# Altium schematic sheet main.SchDoc
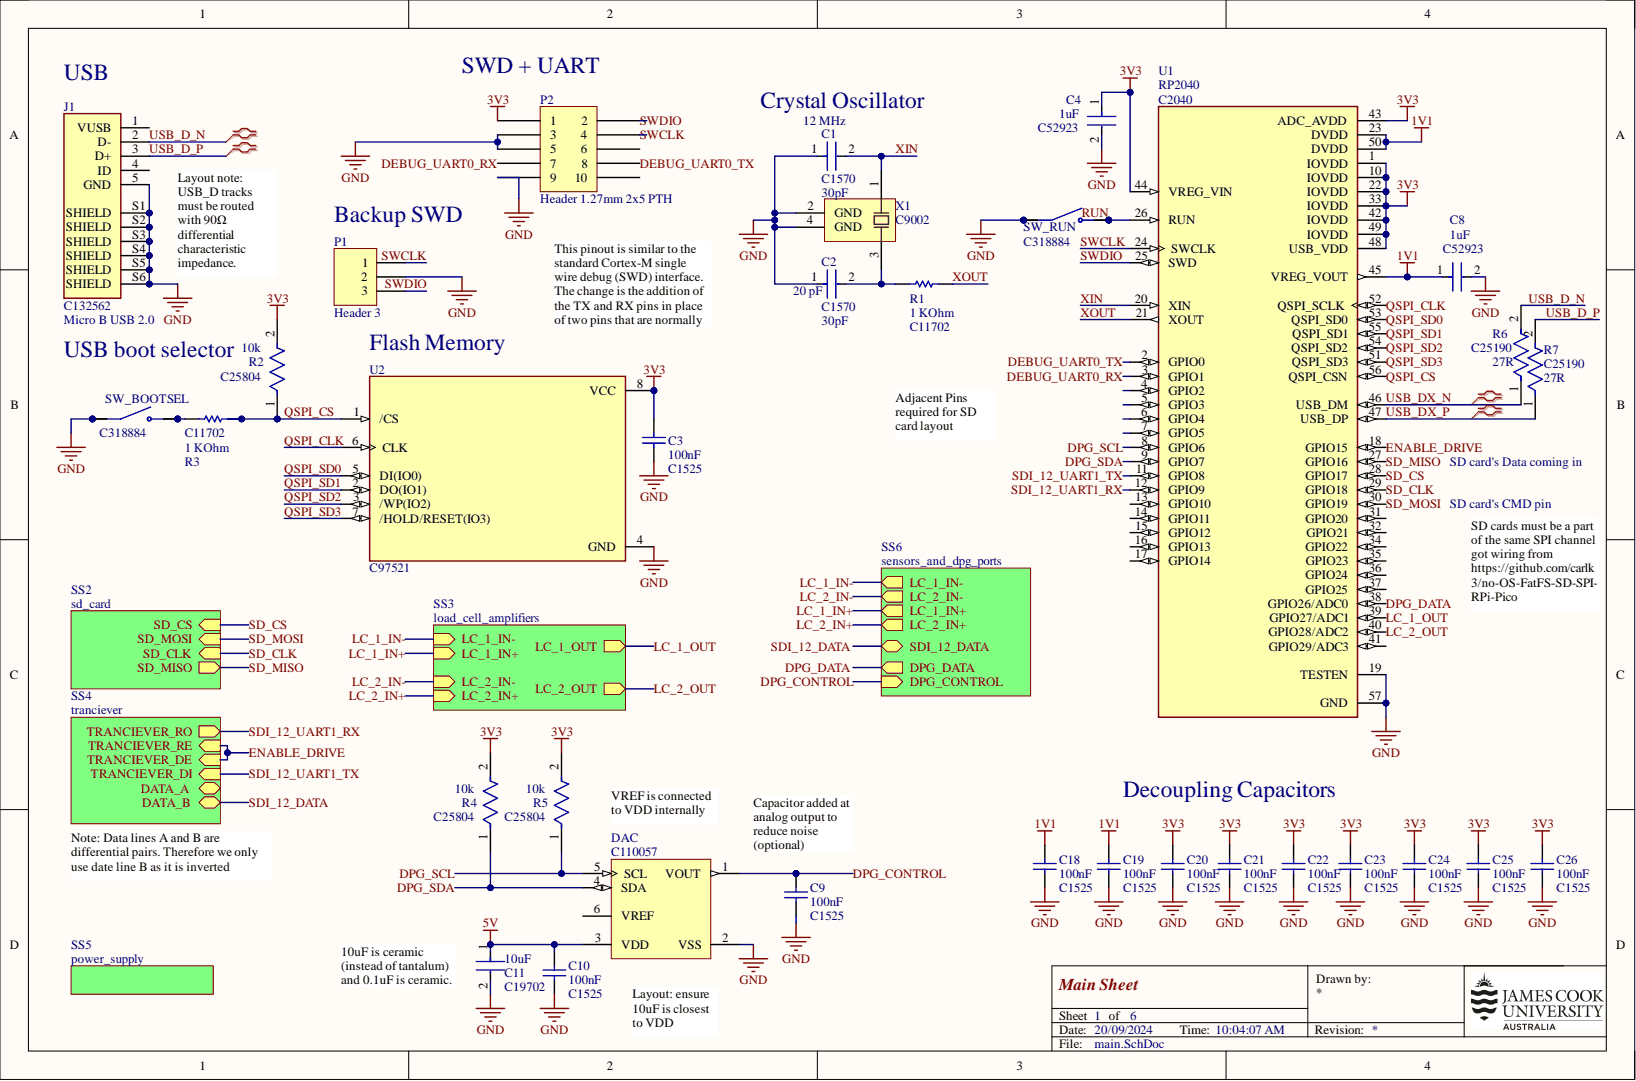
[diagram p0: main.SchDoc]

## Parts
C1  JLCPCB Part: C1570  [FP-0402-L_1_0_1-W_0_5_0_1-IPC_B]  (hidden comment: 0402CG300J500NT)
C2  Capacitance: 30pF  JLCPCB Part: C1570  [FP-0402-L_1_0_1-W_0_5_0_1-IPC_B]  (hidden comment: 0402CG300J500NT)
C3  Capacitance: 100nF  JLCPCB Part: C1525  [CAPC1050X55X23NL05T13]  (hidden comment: CL05B104KO5NNNC)
C4  Capacitance: 1uF  JLCPCB Part: C52923  [FP-CL542-IPC_B]  (hidden comment: CL05A105KA5NQNC)
C8  Capacitance: 1uF  JLCPCB Part: C52923  [FP-CL542-IPC_B]  (hidden comment: CL05A105KA5NQNC)
C9  Capacitance: 100nF  JLCPCB Part: C1525  [CAPC1050X55X23NL05T13]  (hidden comment: CL05B104KO5NNNC)
C10  Capacitance: 100nF  JLCPCB Part: C1525  [CAPC1050X55X23NL05T13]  (hidden comment: CL05B104KO5NNNC)
C11  Capacitance: 10uF  JLCPCB Part: C19702  [FP-CL10-IPC_B]  (hidden comment: CL10A106KP8NNNC)
C18  Capacitance: 100nF  JLCPCB Part: C1525  [CAPC1050X55X23NL05T13]  (hidden comment: CL05B104KO5NNNC)
C19  Capacitance: 100nF  JLCPCB Part: C1525  [CAPC1050X55X23NL05T13]  (hidden comment: CL05B104KO5NNNC)
C20  Capacitance: 100nF  JLCPCB Part: C1525  [CAPC1050X55X23NL05T13]  (hidden comment: CL05B104KO5NNNC)
C21  Capacitance: 100nF  JLCPCB Part: C1525  [CAPC1050X55X23NL05T13]  (hidden comment: CL05B104KO5NNNC)
C22  Capacitance: 100nF  JLCPCB Part: C1525  [CAPC1050X55X23NL05T13]  (hidden comment: CL05B104KO5NNNC)
C23  Capacitance: 100nF  JLCPCB Part: C1525  [CAPC1050X55X23NL05T13]  (hidden comment: CL05B104KO5NNNC)
C24  Capacitance: 100nF  JLCPCB Part: C1525  [CAPC1050X55X23NL05T13]  (hidden comment: CL05B104KO5NNNC)
C25  Capacitance: 100nF  JLCPCB Part: C1525  [CAPC1050X55X23NL05T13]  (hidden comment: CL05B104KO5NNNC)
C26  Capacitance: 100nF  JLCPCB Part: C1525  [CAPC1050X55X23NL05T13]  (hidden comment: CL05B104KO5NNNC)
DAC  JLCPCB Part: C110057  [SOT-23-CH6_M]  (hidden comment: MCP4716A0T-E/CH)
J1  Micro B USB 2.0  JLCPCB Part: C132562  [FCI_10118193-0001LF]
P1  Header 3  [HDR1X3]
P2  Header 1.27mm 2x5 PTH  [Header 1.27mm 2x5 PTH]
R1  Resistance: 1 KOhm  [RESC1005X40X25NL05T05]  (hidden comment: 0402WGF1001TCE)
R2  JLCPCB Part: C25804  Resistance: 10k  [FP-CRCW0603-e3-IPC_B]  (hidden comment: 0603WAF1002T5E)
R3  JLCPCB Part: C11702  Resistance: 1 KOhm  [RESC1005X40X25NL05T05]  (hidden comment: 0402WGF1001TCE)
R4  JLCPCB Part: C25804  Resistance: 10k  [FP-CRCW0603-e3-IPC_B]  (hidden comment: 0603WAF1002T5E)
R5  JLCPCB Part: C25804  Resistance: 10k  [FP-CRCW0603-e3-IPC_B]  (hidden comment: 0603WAF1002T5E)
R6  JLCPCB Part: C25190  Resistance: 27R  [FP-CRCW0603-e3-IPC_B]  (hidden comment: 0603WAF270JT5E)
R7  JLCPCB Part: C25190  Resistance: 27R  [FP-CRCW0603-e3-IPC_B]  (hidden comment: 0603WAF270JT5E)
SW_BOOTSEL  JLCPCB Part: C318884  [SW_TS-1187A-B-A-B]  (hidden comment: TS-1187A-B-A-B)
SW_RUN  JLCPCB Part: C318884  [SW_TS-1187A-B-A-B]  (hidden comment: TS-1187A-B-A-B)
U1  RP2040  JLCPCB Part: C2040  [QFN40P700X700X90-57N]
U2  JLCPCB Part: C97521  [SOIC127P790X216-8N]  (hidden comment: W25Q128JVSIQ)
X1  JLCPCB Part: C9002  [X322512MSB4SI-Footprint-1]  (hidden comment: X322512MSB4SI)

## Nets
1V1: C8.1 C18.2 C19.2 U1.23(DVDD) U1.45(VREG_VOUT) U1.50(DVDD)
3V3: C3.2 C4.1 C20.2 C21.2 C22.2 C23.2 C24.2 C25.2 C26.2 P2.1 R2.2 R4.2 R5.2 U1.1(IOVDD) U1.10(IOVDD) U1.22(IOVDD) U1.33(IOVDD) U1.42(IOVDD) U1.43(ADC_AVDD) U1.44(VREG_VIN) U1.48(USB_VDD) U1.49(IOVDD) U2.8(VCC)
5V: C10.2 C11.1 DAC.3(VDD)
GND: C1.1 C2.1 C3.1 C4.2 C8.2 C9.1 C10.1 C11.2 C18.1 C19.1 C20.1 C21.1 C22.1 C23.1 C24.1 C25.1 C26.1 DAC.2(VSS) J1.5(GND) J1.S1(SHIELD) J1.S2(SHIELD) J1.S3(SHIELD) J1.S4(SHIELD) J1.S5(SHIELD) J1.S6(SHIELD) P1.2 P2.3 P2.5 P2.9 SW_BOOTSEL.A SW_BOOTSEL.B SW_RUN.A SW_RUN.B U1.19(TESTEN) U1.57(GND) U2.4(GND) X1.2(GND) X1.4(GND)
DEBUG_UART0_RX: P2.7 U1.3(GPIO1)
DEBUG_UART0_TX: P2.8 U1.2(GPIO0)
DPG_CONTROL: C9.2 DAC.1(VOUT)
DPG_DATA: U1.38(GPIO26/ADC0)
DPG_SCL: DAC.5(SCL) R5.1 U1.8(GPIO6)
DPG_SDA: DAC.4(SDA) R4.1 U1.9(GPIO7)
ENABLE_DRIVE: U1.18(GPIO15)
LC_1_OUT: U1.39(GPIO27/ADC1)
LC_2_OUT: U1.40(GPIO28/ADC2)
QSPI_CLK: U1.52(QSPI_SCLK) U2.6(CLK)
QSPI_CS: R2.1 R3.2 U1.56(QSPI_CSN) U2.1(/CS)
QSPI_SD0: U1.53(QSPI_SD0) U2.5(DI(IO0))
QSPI_SD1: U1.55(QSPI_SD1) U2.2(DO(IO1))
QSPI_SD2: U1.54(QSPI_SD2) U2.3(/WP(IO2))
QSPI_SD3: U1.51(QSPI_SD3) U2.7(/HOLD/RESET(IO3))
RUN: SW_RUN.C SW_RUN.D U1.26(RUN)
SD_CLK: U1.29(GPIO18)
SD_CS: U1.28(GPIO17)
SD_MISO: U1.27(GPIO16)
SD_MOSI: U1.30(GPIO19)
SDI_12_UART1_RX: U1.12(GPIO9)
SDI_12_UART1_TX: U1.11(GPIO8)
SWCLK: P1.1 P2.4 U1.24(SWCLK)
SWDIO: P1.3 P2.2 U1.25(SWD)
USB_D_N: J1.2(D-) R6.2
USB_D_P: J1.3(D+) R7.2
USB_DX_N: R6.1 U1.46(USB_DM)
USB_DX_P: R7.1 U1.47(USB_DP)
XIN: C1.2 U1.20(XIN) X1.1
XOUT: R1.2 U1.21(XOUT)
NetC2_2: C2.2 R1.1 X1.3
NetDAC_6: DAC.6(VREF)
NetJ1_1: J1.1(VUSB)
NetJ1_4: J1.4(ID)
NetP2_6: P2.6
NetP2_10: P2.10
NetR3_1: R3.1 SW_BOOTSEL.C SW_BOOTSEL.D
NetU1_4: U1.4(GPIO2)
NetU1_5: U1.5(GPIO3)
NetU1_6: U1.6(GPIO4)
NetU1_7: U1.7(GPIO5)
NetU1_13: U1.13(GPIO10)
NetU1_14: U1.14(GPIO11)
NetU1_15: U1.15(GPIO12)
NetU1_16: U1.16(GPIO13)
NetU1_17: U1.17(GPIO14)
NetU1_31: U1.31(GPIO20)
NetU1_32: U1.32(GPIO21)
NetU1_34: U1.34(GPIO22)
NetU1_35: U1.35(GPIO23)
NetU1_36: U1.36(GPIO24)
NetU1_37: U1.37(GPIO25)
NetU1_41: U1.41(GPIO29/ADC3)
DATA_A:
LC_1_IN+:
LC_1_IN-:
LC_2_IN+:
LC_2_IN-:
SDI_12_DATA:

## Library data of the parts
C1 C2 = CMP-006-0002-1: General Purpose Ceramic Capacitor, 0402, 30pF, 5%, C0G, 30ppm/°C, 50V; Insulation Resistance: 100GR; Case Code (Imperial): 0402; Case Code (Metric): 1005; Case/Package: 0402; Component Kind: Standard; Construction: Flat; Contact Plating: Tin; Depth: 0.5mm; Dielectric: C0G; Height: 0.5mm; Lead Free: Lead Free; Length: 1mm; Max Operating Temperature: 125°C; Min Operating Temperature: -55°C; Mount: Surface Mount; Number of Pins: 2; Package Quantity: 1; Packaging: Tape and Reel; Radiation Hardening: No; REACH SVHC: No SVHC; RoHS Compliant: Yes; Series: -; Temperature Coefficient: 30ppm/°C; Termination: SMD/SMT; Thickness: 0.022inch; Tolerance: 5%; Voltage: 50V; Voltage Rating: 50V; Voltage Rating (DC): 50V; Width: 0.5mm; Library Name: James Cook University
  C1: Capacitance: 30pF
C3 C9 C10 C18-C26 = CMP-00007-3: Chip Capacitor, 100 nF, +/- 10%, 16 V, -55 to 125 degC, 0402 (1005 Metric), RoHS, Tape and Reel; Manufacturer: Samsung Electro-Mechanics; Dielectric: X7R; Rated Voltage: 16V; Case Size/Dimension: 0402; Component Kind: Standard; Datasheet URL: https://datasheet.ciiva.com/29198/458718-da-01-en-vs-kondensator-0402-29198423.pdf; Datasheet Version: Nov-10; Manufacturer URL: http://www.samsungsem.com/global/index.jsp; Tolerance (%): ±10%; Library Name: James Cook University
C4 C8 = CMP-00005-3: Cap Ceramic 1uF 25V X5R ±10% SMD 0402 +85°C Paper T/R; Case Code (Imperial): 0402; Case Code (Metric): 1005; Case/Package: 0402; Component Kind: Standard; Depth: 0.5mm; Dielectric: X5R; Height: 0.5mm; Lead Free: Lead Free; Length: 1mm; Material: Ceramic; Max Operating Temperature: 85°C; Min Operating Temperature: -55°C; Mount: Surface Mount; Package Quantity: 10000; Packaging: Tape and Reel; Radiation Hardening: No; Thickness: 0.022inch; Tolerance: 10%; Voltage Rating: 25V; Width: 0.02inch; Library Name: James Cook University
C11 = CMP-00006-4: Cap Ceramic 10uF 10V X5R ±10% Pad SMD 0603 +85°C T/R; Case Code (Imperial): 0603; Case Code (Metric): 1608; Case/Package: 0603; Component Kind: Standard; Length: 0.063inch; Max Operating Temperature: 85°C; Min Operating Temperature: -55°C; Mount: Surface Mount; Packaging: Tape and Reel; Thickness: 0.041inch; Tolerance: 10%; Voltage Rating: 10V; Width: 0.031inch; Library Name: James Cook University
DAC = CMP-0188-01210-1: 10-Bit Voltage Output Digital-to-Analog Converter with EEPROM and I2C Interface, 6-Pin SOT-23, Extended Temperature, Tape and Reel; Code_IPC: SOT26A-6; Component Kind: Standard; ComponentLink1Description: Manufacturer URL; ComponentLink1URL: http://www.microchip.com/; ComponentLink2Description: Datasheet; ComponentLink2URL: http://ww1.microchip.com/downloads/en/DeviceDoc/22272B.pdf; ComponentLink3Description: Package Specification; ComponentLink3URL: http://www.microchip.com/stellent/groups/techpub_sg/documents/packagingspec/en012702.pdf; DatasheetVersion: revB, Sep-2011; Library Name: Altium Content Vault; PackageDescription: 6-Lead Plastic Small Outline Transistor (CH) [SOT-23]; PackageReference: SOT-23-CH6; PackageVersion: revBB, Aug-2009
J1 = CMP-045-000000-8: USB - micro B USB 2.0 Receptacle Connector 5 Position Surface Mount, Right Angle; Through Hole; MF: Amphenol ICC; MP: 10118193-0001LF; Component Kind: Standard; Component Type: Standard; Design Item ID: 10118193-0001LF; Package: None; Pin Count: 11; SnapEDA_Link: https://www.snapeda.com/parts/10118193-0001LF/Amphenol%20FCI/view-part/2758989/?ref=snap; Source: SnapEDAsch.SchLib; Library Name: James Cook University
P1 = CMP-002-00117-1: Header, 3-Pin; Component Kind: Standard; Component Type: Standard; Design Item ID: Header 3; LatestRevisionDate: 17-Jul-2002; LatestRevisionNote: Re-released for DXP Platform.; Pin Count: 3; Signal Integrity: Connector; Source: Miscellaneous Connectors.SchLib; Library Name: James Cook University; Publisher: Altium Limited
P2 = CMP-005-0002-2: Header 1.27mm pitch, 2x5, PTH through hole; Component Kind: Standard; Component Type: Standard; Design Item ID: Header 1.27mm 2x5 PTH; element14 Item: 1577743; Pins: 10; Library Name: James Cook University; Source: JCULibrary.SchLib
R1 R3 = CMP-009-0000-2: Chip Resistor, 1 KOhm, +/- 1%, 100 mW, -55 to 155 degC, 0402 (1005 Metric), RoHS, Tape and Reel; Manufacturer: Basic Passives; Case\/Package: 0402; Power Rating: 100 mW; Voltage Rating: 50 V; Case Code (Imperial): 0402; Case Code (Metric): 1005; Component Kind: Standard; Height: 0.35 mm; Length: 1 mm; Max Operating Temperature: 155 degC; Min Operating Temperature: -55 degC; Mount: Surface Mount; Mounting Technology: Surface Mount; Package Quantity: 10000; PackageDescription: 2-Pin Surface Mount Device, Body 1 x 0.5 mm; PackageReference: 0402; Packaging: Tape and Reel; PartNumber: 0402WGF1001TCE; Pins: 2; Radiation Hardening: No; REACH SVHC: Yes; RoHSCompliant: true; Tolerance: 1%; Width: 0.5 mm; Library Name: James Cook University
  R1: JLCPCB Part: C11702
R2 R4 R5 = CMP-009-0009-1: RES Thick Film, 10kΩ, 1%, 0.1W, 100ppm/°C, 0603; Power Rating: 100mW; Voltage Rating: 75V; Case Code (Imperial): 0603; Case Code (Metric): 1608; Case/Package: 0603; Component Kind: Standard; Composition: Thick Film; Contact Plating: Tin; Depth: 0.85mm; Height: 0.45mm; Lead Free: Lead Free; Length: 1.55mm; Max Operating Temperature: 155°C; Military Standard: Not; Min Operating Temperature: -55°C; Mount: Surface Mount; Number of Pins: 2; Package Quantity: 5000; Packaging: Tape and Reel; Radiation Hardening: No; Temperature Coefficient: 100ppm/°C; Termination: SMD/SMT; Tolerance: 1%; Voltage Rating (DC): 75V; Weight: 7.1E-05oz; Width: 0.85mm; Library Name: James Cook University
R6 R7 = CMP-00004-5: RES Thick Film, 27Ω, 1%, 0.1W, 200ppm/°C, 0603; Power Rating: 100mW; Temperature Coefficient: 100ppm/°C; Voltage Rating: 75V; Case Code (Imperial): 0603; Case Code (Metric): 1608; Case/Package: 0603; Component Kind: Standard; Composition: Thick Film; Contact Plating: Tin; Depth: 0.85mm; Height: 0.45mm; Lead Free: Lead Free; Length: 1.55mm; Max Operating Temperature: 155°C; Military Standard: Not; Min Operating Temperature: -55°C; Mount: Surface Mount; Number of Pins: 2; Package Quantity: 5000; Packaging: Tape and Reel; Radiation Hardening: No; Termination: SMD/SMT; Tolerance: 1%; Voltage Rating (DC): 75V; Weight: 7.1E-05oz; Width: 0.85mm; Library Name: James Cook University
SW_BOOTSEL SW_RUN = CMP-051-000000-5: SPST 50mA @ 12VDC Top Actuated Round Button 160gf SMD Tactile Switches RoHS; Availability: Unavailable; Component Kind: Standard; Component Type: Standard; Design Item ID: TS-1187A-B-A-B; MP: TS-1187A-B-A-B; Package: None; Pin Count: 4; Price: None; Source: TS-1187A-B-A-B.SchLib; Library Name: James Cook University
U1 = CMP-043-000000-5: ARM Cortex-M0+ MCU 32-Bit Microcontroller for Raspberry Pi Pico; Availability: Available; Component Kind: Standard; Component Type: Standard; Design Item ID: RP2040; MP: RP2040; Package: None; Pin Count: 57; Price: None; Source: RP2040.SchLib; Library Name: James Cook University
U2 = CMP-031-00006-4: FLASH - NOR Memory IC 128Mb (16M x 8) SPI - Quad I/O, QPI, DTR 133 MHz 8-SOIC; MF: Winbond Electronics; Availability: In Stock; Check_prices: https://www.snapeda.com/parts/W25Q128JVSIQ/Winbond+Electronics/view-part/?ref=eda; Component Kind: Standard; Component Type: Standard; Design Item ID: W25Q128JVSIQ; MP: W25Q128JVSIQ; Package: SOIC-8 Winbond; Pin Count: 8; Price: None; Purchase-URL: https://pricing.snapeda.com/search/part/W25Q128JVSIQ/?ref=eda; SnapEDA_Link: https://www.snapeda.com/parts/W25Q128JVSIQ/Winbond+Electronics/view-part/?ref=snap; Source: W25Q128JVSIQ.SchLib; Library Name: James Cook University
X1 = CMP-00002-4: 12MHz SMD Crystal Resonator 20pF ±10ppm ±30ppm -40℃~+85℃ SMD3225-4P  Crystals ROHS Yangxing Tech; Max ESR: 80 Ω; Component Kind: Standard; Frequency: 12 MHz; Load capacitance: 20 pF; Library Name: James Cook University
